# Altium schematic sheet Power_Protection.SchDoc
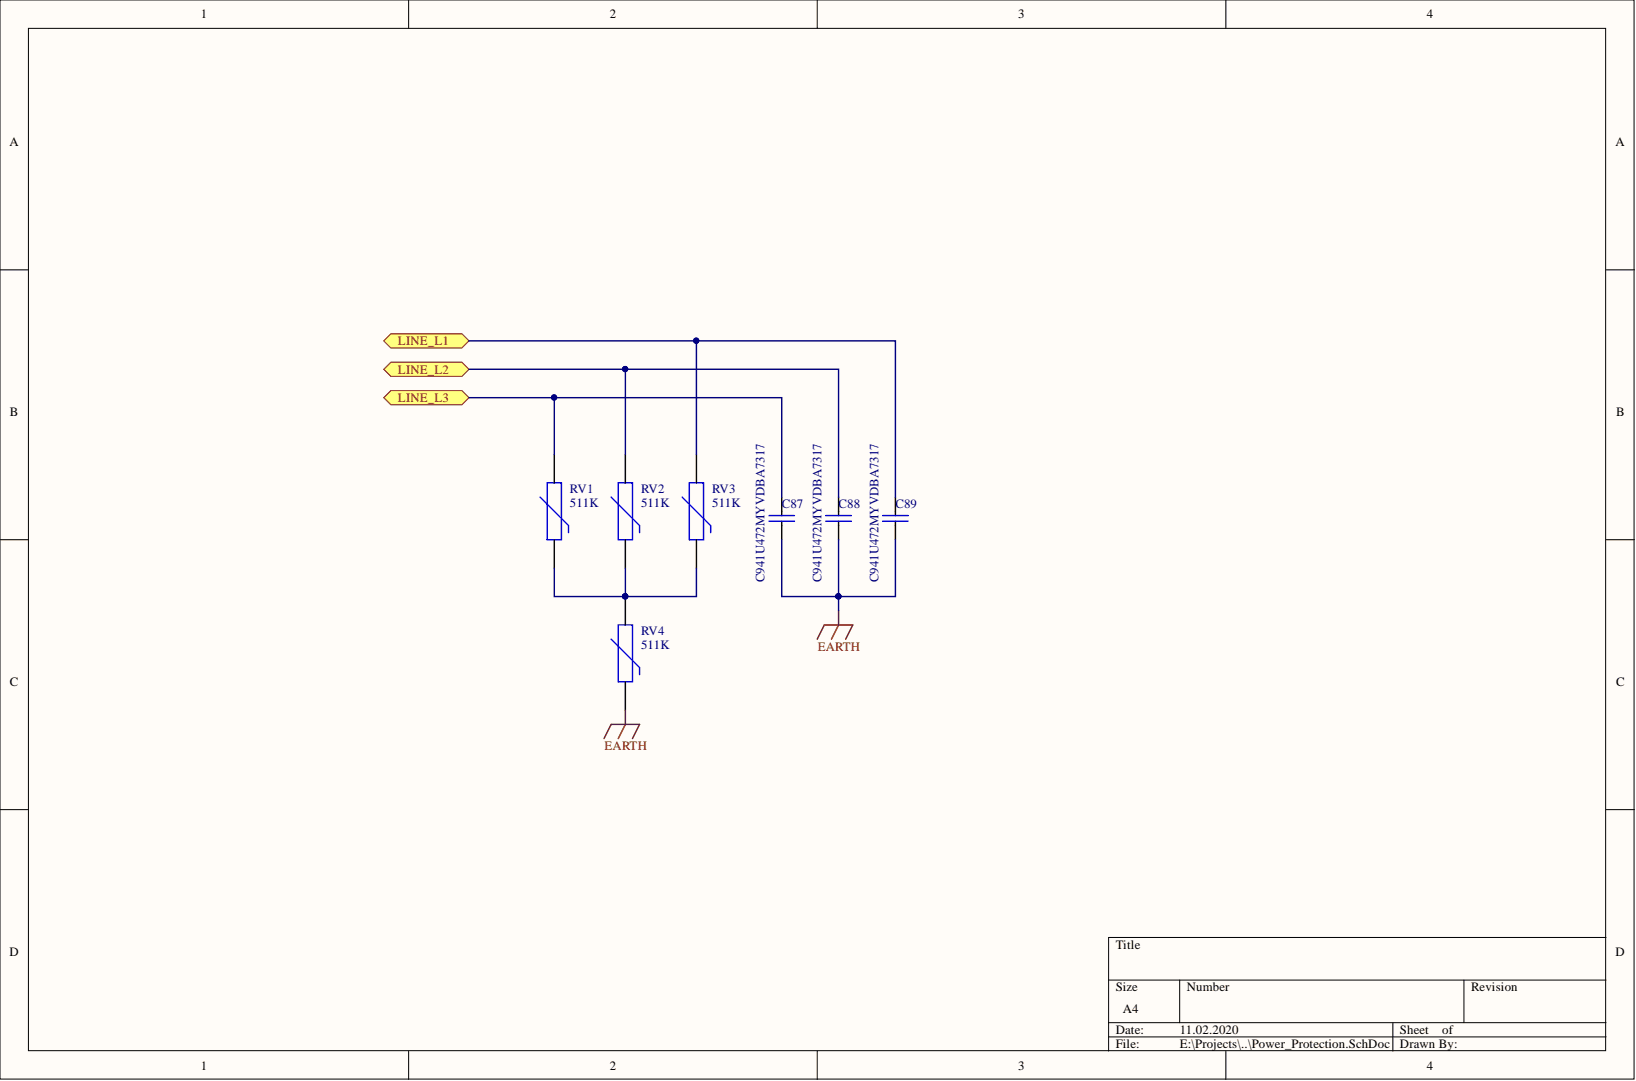
[diagram p0: Power_Protection.SchDoc]

## Parts
C87  C941U472MYVDBA7317  [X1/Y2 C900]
C88  C941U472MYVDBA7317  [X1/Y2 C900]
C89  C941U472MYVDBA7317  [X1/Y2 C900]
RV1  511K  [MOV-D14]
RV2  511K  [MOV-D14]
RV3  511K  [MOV-D14]
RV4  511K  [MOV-D14]

## Ports
LINE_L1, LINE_L2, LINE_L3

## Nets
EARTH: C87.2 C88.2 C89.2 RV4.1
LINE_L1: C89.1 RV3.2 ; port LINE_L1
LINE_L2: C88.1 RV2.2 ; port LINE_L2
LINE_L3: C87.1 RV1.2 ; port LINE_L3
NetRV1_1: RV1.1 RV2.1 RV3.1 RV4.2

## Library data of the parts
C87-C89 = X1/Y2 C900 capacitor: Radial Leaded Ceramic Disc Capacitors Y2/X1; Component Kind: Standard; Library Name: Capacitors.SchLib; Value: C941U472MYVDBA7317
RV1-RV4 = MOV-14D: VARISTOR 510V 4.5KA DISC 14MM; Component Kind: Standard; Value: 511K; Library Name: Protections.SchLib
